# Altium schematic sheet Sheet_BEEE.SchDoc(Sheet_BEEE)
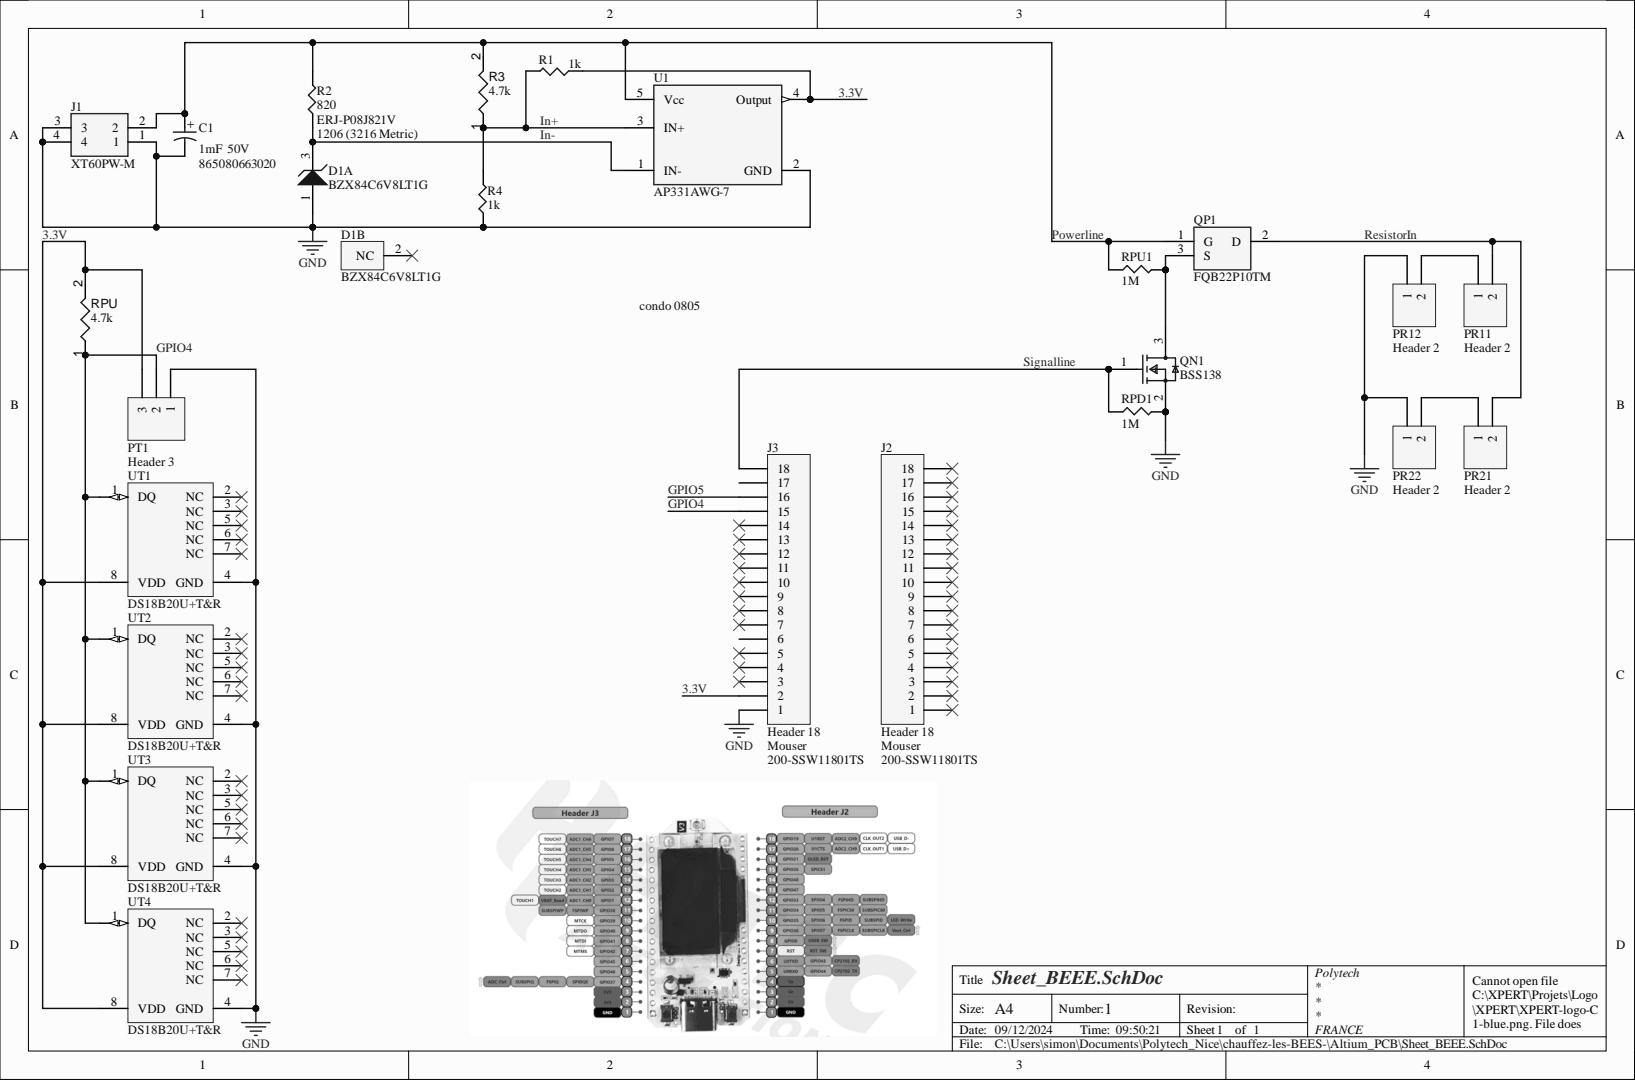
[diagram p0: Sheet_BEEE.SchDoc]

## Parts
C1  865080663020  Capacitance: 1mF  [WCAP-ASLI_D16H17]
D1  BZX84C6V8LT1G  [ONSC-SOT-23-3-318-08_V]  (parts A,B)
J1  XT60PW-M  [XT60PWM]
J2  Header 18  Supplier 2: Mouser  [HDR1X18]
J3  Header 18  Supplier 2: Mouser  [HDR1X18]
PR11  Header 2  [HDR1X2]
PR12  Header 2  [HDR1X2]
PR21  Header 2  [HDR1X2]
PR22  Header 2  [HDR1X2]
PT1  Header 3  [HDR1X3]
QN1  BSS138  [FP-318-08-IPC_B]
QP1  FQB22P10TM  [FQB22P10TM]
R1  value 1k  [SMD-1206-RES]  (hidden comment: ERJ-P08J102V)
R2  ERJ-P08J821V  value 820  Supplier Device Package: 1206  [SMD-1206-RES]
R3  value 4.7k  [RESC3116X70X50ML13T20]  (hidden comment: Resistor 4k7 +/-1% 1206 250 mW)
R4  value 1k  [SMD-1206-RES]  (hidden comment: ERJ-P08J102V)
RPD1  value 1M  [SMD-1206-RES]  (hidden comment: ERJ-8GEYJ105V)
RPU  value 4.7k  [RESC3116X70X50ML13T20]  (hidden comment: Resistor 4k7 +/-1% 1206 250 mW)
RPU1  value 1M  [SMD-1206-RES]  (hidden comment: ERJ-8GEYJ105V)
U1  AP331AWG-7  [FP-SOT25-MFG]
UT1  DS18B20U+T&R  [MAXM-U8+1_M]
UT2  DS18B20U+T&R  [MAXM-U8+1_M]
UT3  DS18B20U+T&R  [MAXM-U8+1_M]
UT4  DS18B20U+T&R  [MAXM-U8+1_M]

## Nets
GND: C1.2 D1.1 J1.1 J1.3 J1.4 J3.1 PR12.1 PR22.1 PT1.1 QN1.2 R4.1 RPD1.2 U1.2(GND) UT1.4(GND) UT2.4(GND) UT3.4(GND) UT4.4(GND)
3.3V: J3.2 PT1.3 R1.1 RPU.2 U1.4(Output) UT1.8(VDD) UT2.8(VDD) UT3.8(VDD) UT4.8(VDD)
GPIO4: J3.15 PT1.2 RPU.1 UT1.1(DQ) UT2.1(DQ) UT3.1(DQ) UT4.1(DQ)
GPIO5: J3.16
In+: R1.2 R3.1 R4.2 U1.3(IN+)
In-: D1.3 R2.1 U1.1(IN-)
Powerline: C1.1 J1.2 QP1.1 R2.2 R3.2 RPU1.1 U1.5(Vcc)
ResistorIn: PR11.2 PR21.2 QP1.2
Signalline: J3.18 QN1.1 RPD1.1
NetPR11_1: PR11.1 PR12.2
NetPR21_1: PR21.1 PR22.2
NetQN1_3: QN1.3 QP1.3 RPU1.2

Not connected: D1 2(NC); J2 1 2 3 4 5 6 7 8 9 10 11 12 13 14 15 16 17 18; J3 3 4 5 6 7 8 9 10 11 12 13 14 17; UT1 2(NC) 3(NC) 5(NC) 6(NC) 7(NC); UT2 2(NC) 3(NC) 5(NC) 6(NC) 7(NC); UT3 2(NC) 3(NC) 5(NC) 6(NC) 7(NC); UT4 2(NC) 3(NC) 5(NC) 6(NC) 7(NC)

## Library data of the parts
C1 = CMP-03913-53914628-1: 865080663020 WCAP-ASLI Aluminum Electrolytic Capacitors; ESR (Equivalent Series Resistance): 60.8615mΩ; Impedance: 78mΩ; Insulation Resistance: 100kΩ; Leakage Current: 500µA; Life (Hours): 2000hours; Polarity: Polar; Ripple Current: 1A; Tolerance: 20%; Voltage Rating: 50V; Case/Package: Radial; Component Kind: Standard; Diameter: 16mm; Height: 17mm; Height - Seated (Max): 16.9926mm; Length: 17mm; Library Name: Altium Content Vault; Max Operating Temperature: 105°C; Min Operating Temperature: -55°C; Mount: Surface Mount; NexarPartId: 53914628; Packaging: Tape & Reel (TR); REACH SVHC: Unknown; Ripple Current (AC): 1A; RoHS: Compliant; Voltage Rating (DC): 50V; Width: 17.1mm
D1 = CMP-1060-00902-1: Zener Voltage Regulator, 225 mW, 3-Pin SOT-23, Pb-Free, Tape and Reel; Manufacturer: On Semiconductor; Component Kind: Standard; ComponentLink1Description: Manufacturer URL; ComponentLink1URL: http://www.onsemi.com/; ComponentLink2Description: Datasheet; ComponentLink2URL: http://www.onsemi.com/pub_link/Collateral/BZX84C2V4LT1-D.PDF; ComponentLink3Description: Package Specification; ComponentLink3URL: http://www.onsemi.cn/pub_link/Collateral/318-08.PDF; DatasheetVersion: Rev. 17; Library Name: Altium Content Vault; Mounting Technology: Surface Mount; PackageDescription: 3-Pin Small Outline Transistor, Body 2.92 x 1.3 mm, Pitch 0.95 mm; PackageReference: SOT-23-3-318-08; PackageVersion: Rev. AP, 11/2009; Packing: Tape and Reel; PartNumber: BZX84C6V8LT1G; RoHS: Pb-Free
J1 = XT60PW-M: Connector; Manufacturer_Name: Changzou Amass Elec; Component Kind: Standard; Datasheet Link: https://www.tme.eu/Document/9b8d0c5eb7094295f3d3112c214d3ade/XT60PW%20SPEC.pdf; Height: 8.4mm; Manufacturer_Part_Number: XT60PW-M
J2 J3 = Header 18: Header, 18-Pin; Component Kind: Standard; LatestRevisionDate: 17-Jul-2002; LatestRevisionNote: Re-released for DXP Platform.; Library Name: Miscellaneous Connectors.IntLib; Signal Integrity: Connector; Supplier 1: Rs; Supplier Part Number 1: 2079233; Supplier Part Number 2: 200-SSW11801TS; Publisher: Altium Limited
PR11 PR12 PR21 PR22 = Header 2: Header, 2-Pin; Component Kind: Standard; LatestRevisionDate: 17-Jul-2002; LatestRevisionNote: Re-released for DXP Platform.; Library Name: Miscellaneous Connectors.IntLib; Signal Integrity: Connector; Publisher: Altium Limited
PT1 = Header 3: Header, 3-Pin; Component Kind: Standard; LatestRevisionDate: 17-Jul-2002; LatestRevisionNote: Re-released for DXP Platform.; Library Name: Miscellaneous Connectors.IntLib; Signal Integrity: Connector; Publisher: Altium Limited
QN1 = CMP-07173-000101-1: MOSFET N-CH 50V 220MA SOT-23; Drain to Source Resistance: 3.5R; Element Configuration: Single; Fall Time: 9ns; Gate to Source Voltage (Vgs): 20V; Input Capacitance: 27pF; Max Power Dissipation: 360mW; Nominal Vgs: 1.3V; Number of Elements: 1; On-State Resistance: 6R; Turn-Off Delay Time: 20ns; Turn-On Delay Time: 2.5ns; Case/Package: SOT-23; Component Kind: Standard; Contact Plating: Tin; Continuous Drain Current (ID): 220mA; Current Rating: 220mA; Drain to Source Breakdown Voltage: 50V; Drain to Source Voltage (Vdss): 50V; Dual Supply Voltage: 50V; Height: 0.93mm; Lead Free: Lead Free; Length: 2.92mm; Library Name: Altium Content Vault; Max Operating Temperature: 150°C; Min Operating Temperature: -55°C; Mount: Surface Mount; Number of Pins: 3; Package Quantity: 1; Packaging: Tape and Reel; Power Dissipation: 360mW; Radiation Hardening: No; Rds On Max: 3.5R; REACH SVHC: No SVHC; Rise Time: 9ns; Termination: SMD/SMT; Threshold Voltage: 1.3V; Voltage Rating (DC): 50V; Weight: 0.03g; Width: 1.3mm
QP1 = FQB22P10TM: MOSFET (P-Channel); Manufacturer_Name: onsemi; Arrow Part Number: FQB22P10TM; Arrow Price/Stock: https://www.arrow.com/en/products/fqb22p10tm/on-semiconductor?region=europe; Component Kind: Standard; Datasheet Link: https://www.onsemi.cn/download/data-sheet/pdf/fqb22p10-d.pdf; Height: 5.08mm; Manufacturer_Part_Number: FQB22P10TM; Mouser Part Number: 512-FQB22P10TM; Mouser Price/Stock: https://www.mouser.co.uk/ProductDetail/ON-Semiconductor-Fairchild/FQB22P10TM?qs=u3sZpSG73%252B7bL0dxrg7qrg%3D%3D
R1 R4 = CMP-015-001023-1: RES SMD 1K OHM 5% 2/3W 1206; Manufacturer: Panasonic Electronic Components; @ qty: 1500; Factory Stock: 0; Minimum Quantity: 1; Power (Watts): 0.667W, 2/3W; Temperature Coefficient: ±200ppm/°C; Unit Price (USD): 0.02178; Component Kind: Standard; Composition: Thick Film; Datasheets: https://industrial.panasonic.com/cdbs/www-data/pdf/RDA0000/AOA0000C243.pdf; Digi-Key Part Number: P1.0KALCT-ND; Failure Rate: -; Features: Automotive AEC-Q200, Pulse Withstanding; Height - Seated (Max): 0.028" (0.70mm); Image: //media.digikey.com/Renders/Panasonic%20Renders/ERJ-1206%20Pkg.jpg; Number of Terminations: 2; Operating Temperature: -55°C ~ 155°C; Package / Case: 1206 (3216 Metric); Packaging: Cut Tape (CT); Part Number: ERJ-P08J102V; Part Status: Active; Quantity Available: 85126; Series: ERJ; Size / Dimension: 0.126" L x 0.063" W (3.20mm x 1.60mm); Supplier Device Package: 1206; Tolerance: ±5%; Library Name: XPERT
R2 = CMP-015-001133-1: RES SMD 820 OHM 5% 2/3W 1206; Manufacturer: Panasonic Electronic Components; @ qty: 1500; Factory Stock: 0; Minimum Quantity: 1; Power (Watts): 0.667W, 2/3W; Temperature Coefficient: ±200ppm/°C; Unit Price (USD): 0.02178; Component Kind: Standard; Composition: Thick Film; Datasheets: https://industrial.panasonic.com/cdbs/www-data/pdf/RDA0000/AOA0000C243.pdf; Digi-Key Part Number: P820ALCT-ND; Failure Rate: -; Features: Automotive AEC-Q200, Pulse Withstanding; Height - Seated (Max): 0.028" (0.70mm); Image: //media.digikey.com/Renders/Panasonic%20Renders/ERJ-1206%20Pkg.jpg; Number of Terminations: 2; Operating Temperature: -55°C ~ 155°C; Package / Case: 1206 (3216 Metric); Packaging: Cut Tape (CT); Part Number: ERJ-P08J821V; Part Status: Active; Quantity Available: 13581; Series: ERJ; Size / Dimension: 0.126" L x 0.063" W (3.20mm x 1.60mm); Tolerance: ±5%; Library Name: XPERT
R3 RPU = CMP-009-00033-5: Chip Resistor, 4.7 KOhm, +/- 1%, 0.25 W, -55 to 155 degC, 1206 (3216 Metric); Power: 250mW; Voltage Rating: 200V; Case/Package: 1206; Component Kind: Standard; Max Operating Temperature: 155°C; Min Operating Temperature: -55°C; Mounting Technology: SM; Pins: 2; RoHS Compliant: True; Tolerance: 1%; Library Name: XPERT
RPD1 RPU1 = CMP-015-000768-1: RES SMD 1M OHM 5% 1/4W 1206; Manufacturer: Panasonic Electronic Components; @ qty: 1500; Factory Stock: 0; Minimum Quantity: 1; Power (Watts): 0.25W, 1/4W; Temperature Coefficient: ±200ppm/°C; Unit Price (USD): 0.00700; Component Kind: Standard; Composition: Thick Film; Datasheets: http://industrial.panasonic.com/www-cgi/jvcr13pz.cgi?E+PZ+3+AOA0001+ERJ8GEYJ105V+7+WW; Digi-Key Part Number: P1.0MECT-ND; Failure Rate: -; Features: Automotive AEC-Q200; Height - Seated (Max): 0.028" (0.70mm); Image: //media.digikey.com/Renders/Panasonic%20Renders/ERJ-1206%20Pkg.jpg; Number of Terminations: 2; Operating Temperature: -55°C ~ 155°C; Package / Case: 1206 (3216 Metric); Packaging: Cut Tape (CT); Part Number: ERJ-8GEYJ105V; Part Status: Active; Quantity Available: 249847; Series: ERJ; Size / Dimension: 0.126" L x 0.063" W (3.20mm x 1.60mm); Supplier Device Package: 1206; Tolerance: ±5%; Library Name: XPERT
U1 = CMP-12735-000001-1: IC COMPARATOR SGL DIFF SOT-25; Manufacturer: Diodes Inc.; Input Bias Current: 0.15uA; Input Offset Voltage: 4mV; Max Dual Supply Voltage: 18V; Max Junction Temp: +150°C; Max Supply Voltage: 36V; Min Dual Supply Voltage: 1V; Min Supply Voltage: 2V; Nominal Supply Current: 0.4-1mA; Number Of Channels: 1; Output Current Per Channel: 16mA; Response Time: 300ns; Standoff Height: 0.013mm; Voltage Gain: 200V/mV; Ambient Temperature Range High: +70°C; Ambient Temperature Range Low: 0°C; Automotive: No; Category: IC; Component Kind: Standard; ComponentLink2Description: Datasheet; ComponentLink2URL: https://www.diodes.com/assets/Datasheets/AP331A.pdf; Device Class L1: Integrated Circuits (ICs); Device Class L2: Linear ICs; Device Class L3: Comparators; Digikey Description: IC COMPARATOR SGL DIFF SOT-25; Footprint Url: https://www.diodes.com/assets/Package-Files/SOT25.pdf; Height: 1.4mm; Lead Free: Yes; Library Name: Altium Content Vault; Manufacturer Part Number: AP331AWG-7; Mouser Description: Analog Comparators 2V-36V 2.5mA 2V-36V 2.5mA; Octopart: https://octopart.com/ap331awg-7-diodes+inc.-12688108; Package: SOT25; RoHS: TRUE; Temperature: 0°C to +70°C; Temperature Range High: +70°C; Temperature Range Low: 0°C
UT1-UT4 = CMP-0253-00024-2: High-Precision 1-Wire Digital Thermometer, -55 to 125 degC, 8-Pin SOP (U8+1), Pb-Free, Tape and Reel; Multi Droppable: Yes; Sensor Type: Local; Temp. Thresh.: Programmable (NV); Code_JEDEC: MO-187; Component Kind: Standard; ComponentLink1Description: Manufacturer URL; ComponentLink1URL: http://www.maximintegrated.com/; ComponentLink2Description: Datasheet; ComponentLink2URL: http://datasheets.maximintegrated.com/en/ds/DS18B20.pdf; ComponentLink3Description: Package Specification; ComponentLink3URL: http://pdfserv.maximintegrated.com/package_dwgs/21-0036.PDF; DatasheetVersion: Rev. 4; Generic Part Number: DS18B20; Interface: 1-Wire; Library Name: Altium Content Vault; Mounting Technology: Surface Mount; PackageDescription: 8-Pin Micro-MAX, Thin Shrink Small-Outline (U8+1), Body 3 x 3 mm, Pitch 0.65 mm; PackageReference: U8+1; PackageVersion: Rev. L, 08/2009; Packing: Tape and Reel; Parasite Pwr.: Yes; PartNumber: DS18B20U+T&R; RoHS: Pb-Free
